# Altium schematic sheet Fuel_Gauge.SchDoc
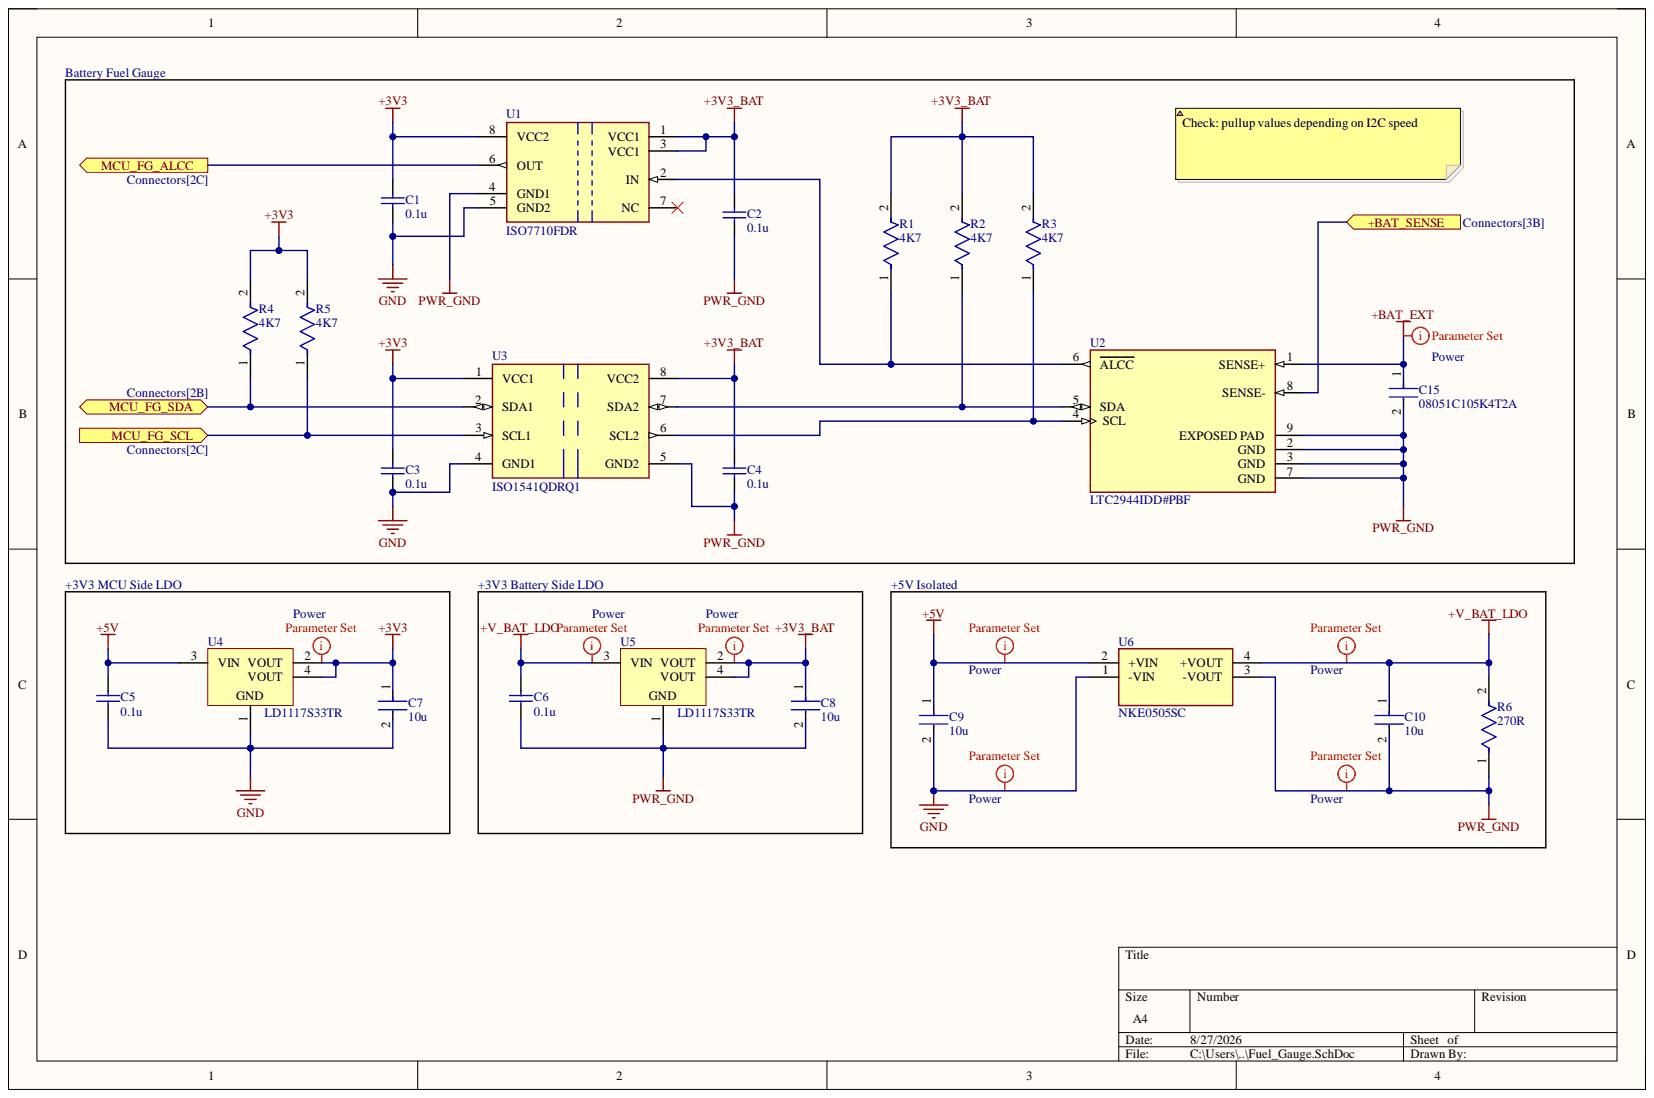
[diagram p0: Fuel_Gauge.SchDoc]

## Parts
C1  0.1u  [CAPC2013X145X48NL20T23]
C2  0.1u  [CAPC2013X145X48NL20T23]
C3  0.1u  [CAPC2013X145X48NL20T23]
C4  0.1u  [CAPC2013X145X48NL20T23]
C5  0.1u  [CAPC2013X145X48NL20T23]
C6  0.1u  [CAPC2013X145X48NL20T23]
C7  10u  [FP-CC1206-FD-MFG]
C8  10u  [FP-CC1206-FD-MFG]
C9  10u  [FP-CC1206-FD-MFG]
C10  10u  [FP-CC1206-FD-MFG]
C15  08051C105K4T2A  [FP-0805-L_2_01_0_2-W_1_25-MFG]
R1  4K7  [FP-RT0805-MFG]
R2  4K7  [FP-RT0805-MFG]
R3  4K7  [FP-RT0805-MFG]
R4  4K7  [FP-RT0805-MFG]
R5  4K7  [FP-RT0805-MFG]
R6  270R  [FP-AF1206-IPC_A]
U1  ISO7710FDR  [FP-D0008B-MFG]
U2  LTC2944IDD#PBF  [FP-DD-8-05-08-1698-MFG]
U3  ISO1541QDRQ1  [FP-D0008A-IPC_B]
U4  LD1117S33TR  [SOT-223_L]
U5  LD1117S33TR  [SOT-223_L]
U6  NKE0505SC  [FP-NKE-SIP-MFG]

## Ports
+BAT_SENSE, MCU_FG_ALCC, MCU_FG_SCL, MCU_FG_SDA

## Nets
+3V3: C1.2 C3.2 C7.1 R4.2 R5.2 U1.8(VCC2) U3.1(VCC1) U4.2(VOUT) U4.4(VOUT)
+3V3_BAT: C2.2 C4.2 C8.1 R1.2 R2.2 R3.2 U1.1(VCC1) U1.3(VCC1) U3.8(VCC2) U5.2(VOUT) U5.4(VOUT)
+5V: C5.2 C9.1 U4.3(VIN) U6.2(+VIN)
+BAT_EXT: C15.1 U2.1(SENSE+)
+V_BAT_LDO: C6.2 C10.1 R6.2 U5.3(VIN) U6.4(+VOUT)
GND: C1.1 C3.1 C5.1 C7.2 C9.2 U1.5(GND2) U3.4(GND1) U4.1 U6.1(-VIN)
PWR_GND: C2.1 C4.1 C6.1 C8.2 C10.2 C15.2 R6.1 U1.4(GND1) U2.2(GND) U2.3(GND) U2.7(GND) U2.9(EXPOSED_PAD) U3.5(GND2) U5.1 U6.3(-VOUT)
+BAT_SENSE: U2.8(SENSE-) ; port +BAT_SENSE
MCU_FG_ALCC: U1.6(OUT) ; port MCU_FG_ALCC
MCU_FG_SCL: R5.1 U3.3(SCL1) ; port MCU_FG_SCL
MCU_FG_SDA: R4.1 U3.2(SDA1) ; port MCU_FG_SDA
NetR1_1: R1.1 U1.2(IN) U2.6(ALCC)
NetR2_1: R2.1 U2.5(SDA) U3.7(SDA2)
NetR3_1: R3.1 U2.4(SCL) U3.6(SCL2)

Not connected: U1 7(NC)

## Library data of the parts
C1-C6 = CMP-2007-01705-1: Manufacturer: Vitramon; Part Number: VJ0805Y104KXAMR; Component Kind: Standard; Library Name: Altium Content Vault
C7-C10 = CMP-03422-001420-1: CAP CER 10UF 50V X5R 1206; Tolerance: 10%; Capacitance: 10uF; Case/Package: 1206; Component Kind: Standard; Dielectric: X5R; Halogen Free: Halogen Free; Lead Free: Lead Free; Length: 0.126inch; Library Name: Altium Content Vault; Max Operating Temperature: 85°C; Min Operating Temperature: -55°C; Mount: Surface Mount; Packaging: Tape and Reel; RoHS Compliant: Yes; Thickness: 0.075inch; Voltage Rating: 50V; Voltage Rating (DC): 50V; Width: 0.063inch
C15 = CMP-07738-122049624-1: 08051C105K4T2A Cap Ceramic 1uF 100V X7R 10% Pad SMD 0805 125C Automotive T/R; Capacitance: 1µF; Case/Package: 0805; Component Kind: Standard; Dielectric: X7R; Height: 1.4mm; Library Name: Altium Content Vault; Lifecycle Status: Production; Material: Ceramic; Max Operating Temperature: 125°C; Min Operating Temperature: -55°C; NexarPartId: 122049624; RoHS: Compliant; Schedule B: 8532240020; Tolerance: 10%; Voltage Rating: 100V; Voltage Rating (DC): 100V
R1-R5 = CMP-03412-025396-1: RT0805 4.7KΩ 0.125W 50 ppm/°C 0.01%; Manufacturer: Yageo; Manufacturer Part Number: RT0805FRE134K7L; Tolerance: 0.0001; Voltage Rating: 150V; Automotive: No; Category: Res; Component Kind: Standard; ComponentLink2Description: Datasheet; ComponentLink2URL: http://www.yageo.com/documents/recent/PYu-RT_1-to-0.01_RoHS_L_9.pdf; Composition: Thin Film; Device Class L1: Passive Components; Device Class L2: Resistors; Device Class L3: Chip SMD Resistors; Footprint Url: http://www.yageo.com/exep/pages/download/literatures/PYu-R_Mount_10.pdf; Height: 0.6mm; Ipc Land Pattern Name: RESC200125X50; Lead Free: Yes; Library Name: Altium Content Vault; Octopart: https://octopart.com/rt0805fre134k7l-yageo-16262042; Package: RT0805; Power Rating: 0.125W; Resistance: 4.7KΩ; RoHS: TRUE; Series: RT; Temperature: -55°C to +155°C; Temperature Coefficient: 50 ppm/°C; Temperature Range High: +155°C; Temperature Range Low: -55°C; Value: 4.7KΩ
R6 = CMP-03412-047403-1: Anti-Sulfurated Chip Resistor Automotive Grade 270Ω 5% 0.25W ±100ppm/°C 1206; Component Kind: Standard; Library Name: Altium Content Vault
U1 = CMP-04872-000020-1: DGTL ISO 3000VRMS 1CH GP 8SOIC; Number of Channels: 1; Component Kind: Standard; Library Name: Altium Content Vault; Max Operating Temperature: 125°C; Min Operating Temperature: -55°C
U2 = CMP-09132-000003-1: IC BATTERY GAS GAUGE I2C 8DFN; Manufacturer: Analog Devices; Max Supply Voltage: 60V; Min Supply Voltage: 3.6V; Nominal Supply Current: 15-850uA; Number Of Cells: 1; Standoff Height: 0mm; Ambient Temperature Range High: +85°C; Ambient Temperature Range Low: -40°C; Automotive: No; Category: IC; Component Kind: Standard; ComponentLink2Description: Datasheet; ComponentLink2URL: https://www.analog.com/media/en/technical-documentation/data-sheets/2944fa.pdf; Device Class L1: Integrated Circuits (ICs); Device Class L2: Power Management ICs; Device Class L3: Battery Management; Digikey Description: IC BATTERY GAS GAUGE I2C 8DFN; Height: 0.8mm; Ipc Land Pattern Name: DFN300X300X75-8; Lead Free: Yes; Library Name: Altium Content Vault; Manufacturer Part Number: LTC2944IDD#PBF; Mouser Description: LTC2944 - 60V Battery Gas Gauge with Temperature, Voltage and Current Measurement; Number Of Outputs: 1; Octopart: https://octopart.com/ltc2944idd%23pbf-analog+devices-88318751; Package: DD-8-05-08-1698; RoHS: TRUE; Temperature: -40°C to +85°C; Temperature Range High: +85°C; Temperature Range Low: -40°C
U3 = CMP-04872-000096-1: AUTO ISO I2C 2500VRMS 2CH 8SOIC; Channel Type: Bidirectional; Data Rate: 1Mbps; Isolated Power: No; Number of Channels: 2; Pulse Width Distortion (PWD): 21ns; Working Voltage: 560V; Case/Package: SOIC; Component Kind: Standard; Library Name: Altium Content Vault; Max Operating Temperature: 125°C; Min Operating Temperature: -40°C; Mount: Surface Mount; Number of Pins: 8; Packaging: Cut Tape; RoHS Compliant: Yes
U4 U5 = CMP-0244-00310-1: Fixed Low Drop Positive Voltage Regulator, 3.3V, 4-Pin SOT-223, Tape and Reel; Component Kind: Standard; ComponentLink1Description: Manufacturer URL; ComponentLink1URL: http://www.st.com; ComponentLink2Description: Datasheet; ComponentLink2URL: http://www.st.com/internet/com/TECHNICAL_RESOURCES/TECHNICAL_LITERATURE/DATASHEET/CD00000544.pdf; DatasheetVersion: 1/11/2011; Library Name: Altium Content Vault; PackageDescription: 4-Pin SOT-223 Package, 6.5 x 3.5 mm Body, 2.3 mm Pitch, 7 mm Lead Span; PackageReference: SOT-223; PackageVersion: 2010
U6 = CMP-20596-000001-1: DC DC CONVERTER 12V 1W; Manufacturer: Murata Power Solutions Inc; Manufacturer Part Number: NKE1212SC; Max Supply Voltage: 13.2V; Min Supply Voltage: 10.8V; Nominal Supply Current: 105mA; Number Of Outputs: 1; Output Current: 83mA; Output Type: Fixed; Standoff Height: 0.4mm; Switching Frequency: 115kHz; Switching Topology: Boost; Automotive: No; Category: IC; Component Kind: Standard; ComponentLink2Description: Datasheet; ComponentLink2URL: https://power.murata.com/pub/data/power/ncl/kdc_nke.pdf; Device Class L1: Integrated Circuits (ICs); Device Class L2: Power Management ICs; Device Class L3: Voltage Regulators - Switching; Digikey Description: DC DC CONVERTER 12V 1W; Height: 7.71mm; Lead Free: Yes; Library Name: Altium Content Vault; Max Power Dissipation: 1W; Mouser Description: Isolated DC/DC Converters 1W SNGL OUT 12-12V Single Output; Octopart: https://octopart.com/nke1212sc-murata+power+solutions-49448374; Output Voltage: 12V; Package: NKE-SIP; RoHS: TRUE; Temperature: -40°C to +85°C; Temperature Range High: +85°C; Temperature Range Low: -40°C
